# Altium schematic sheet CW341_FPGA_CFG.SchDoc
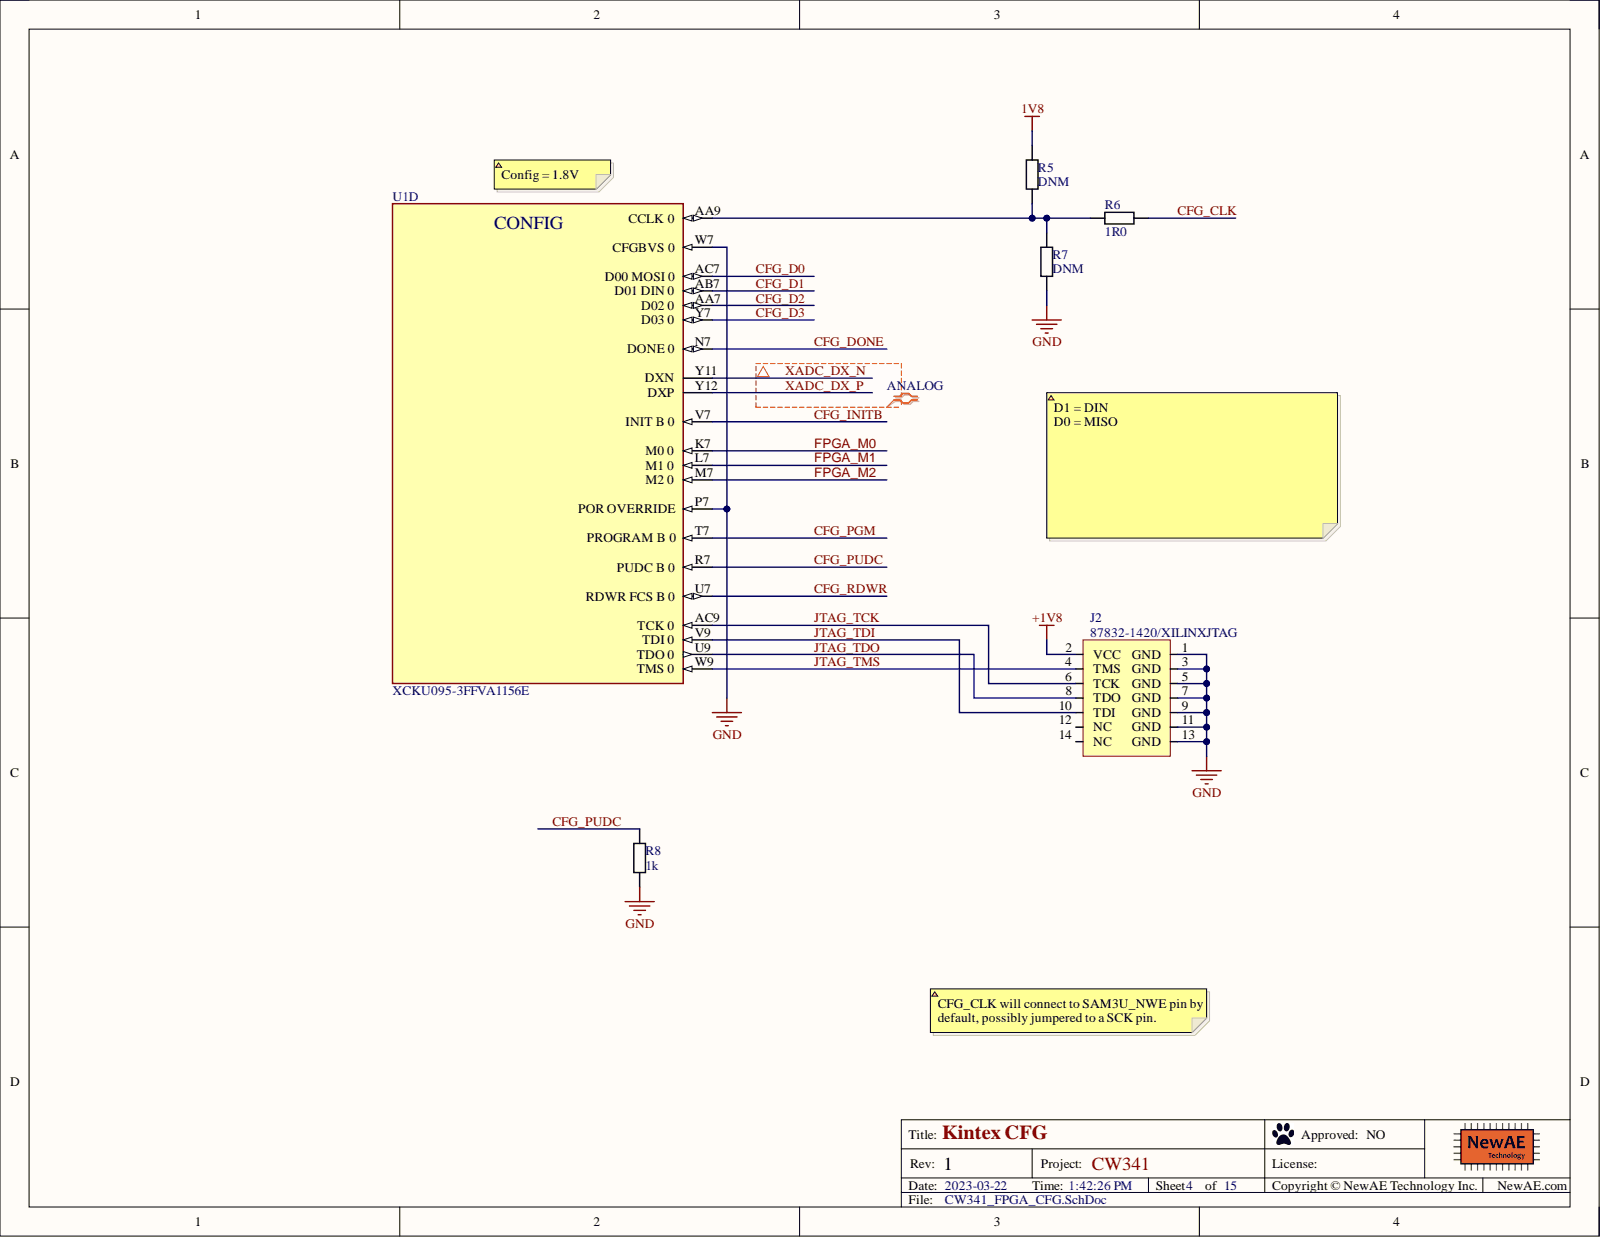
[diagram p0: CW341_FPGA_CFG.SchDoc]

## Parts
J2  87832-1420/XILINXJTAG  [87832-1420]
R5  DNM  [0402]
R6  1R0  [0402]
R7  DNM  [0402]
R8  1k  [0402]
U1  XCKU095-3FFVA1156E  Max Supply Voltage: 1.8V  [FP-XCKU060-3FFVA1156E-MFG]  (part D)

## Nets
1V8: J2.2(VCC) R5.1
GND: J2.1(GND) J2.3(GND) J2.5(GND) J2.7(GND) J2.9(GND) J2.11(GND) J2.13(GND) R7.2 R8.2 U1.P7(POR_OVERRIDE) U1.W7(CFGBVS_0)
CFG_CLK: R6.2
CFG_D0: U1.AC7(D00_MOSI_0)
CFG_D1: U1.AB7(D01_DIN_0)
CFG_D2: U1.AA7(D02_0)
CFG_D3: U1.Y7(D03_0)
CFG_DONE: U1.N7(DONE_0)
CFG_INITB: U1.V7(INIT_B_0)
CFG_PGM: U1.T7(PROGRAM_B_0)
CFG_PUDC: R8.1 U1.R7(PUDC_B_0)
CFG_RDWR: U1.U7(RDWR_FCS_B_0)
FPGA_M0: U1.K7(M0_0)
FPGA_M1: U1.L7(M1_0)
FPGA_M2: U1.M7(M2_0)
JTAG_TCK: J2.6(TCK) U1.AC9(TCK_0)
JTAG_TDI: J2.10(TDI) U1.V9(TDI_0)
JTAG_TDO: J2.8(TDO) U1.U9(TDO_0)
JTAG_TMS: J2.4(TMS) U1.W9(TMS_0)
XADC_DX_N: U1.Y11(DXN)
XADC_DX_P: U1.Y12(DXP)
NetR5_2: R5.2 R6.1 R7.1 U1.AA9(CCLK_0)

Not connected: J2 12(NC) 14(NC)

## Library data of the parts
J2 = 87832-1420/XILINXJTAG: Molex 14-pin SMD, JTAG Pinout; Component Kind: Standard; Library Name: CW1200_MainBoard.SCHLIB; Manufacture 1: Molex; Manufacture 1 Part Number: 87832-1420; Signal Integrity: Guessed Model; Supplier 1: Digi-Key; Supplier Part Number 1: WM18641-ND
R5 R7 = RCHIP-DNM-0402: Case-Metric: 1005; Component Group: Resistor; Database Table Name: main; Case-EIA: 0402; Component Kind: Standard; Component Type: Standard; Library Name: NewAE_AltiumLib.DBLib; Part Number: RCHIP-DNM-0402; Pin Count: 2; Value: DNM
R6 = RCHIP-1R0-0402: 1R0 res, 0402, 1%, 1/16W; Manufacture 1: Yageo; Manufacture Part Number 1: RC0402FR-071RL; Case-Metric: 1005; Component Group: Resistor; Database Table Name: main; Rated Power: 0.063W; Rated Voltage: 75VDC; Case-EIA: 0402; Component Kind: Standard; Component Type: Standard; Library Name: NewAE_AltiumLib.DBLib; Mounting Technology: SMT; Part Number: RCHIP-1R0-0402; Pin Count: 2; Supplier 1: Digi-Key; Supplier Part Number 1: 311-1.00LRCT-ND; Temperature Range: -55C to 155C; Tolerance: 1%; Value: 1R0
R8 = RCHIP-1K-0402: 1k res, 0402, 1%, 1/16W; Manufacture 1: Yageo; Manufacture Part Number 1: RC0402FR-071KL; Case-Metric: 1005; Component Group: Resistor; Database Table Name: main; Rated Power: 0.063W; Rated Voltage: 75VDC; Case-EIA: 0402; Component Kind: Standard; Component Type: Standard; Library Name: NewAE_AltiumLib.DBLib; Mounting Technology: SMT; Part Number: RCHIP-1K-0402; Pin Count: 2; Supplier 1: Digi-Key; Supplier Part Number 1: 311-1.00KLRCT-ND; Temperature Range: -55C to 155C; Tolerance: 1%; Value: 1k
U1 = IC-XCKU095-1156: IC FPGA 520 I/O 1156FCBGA; Manufacturer: Xilinx; Manufacturer Part Number: XCKU060-3FFVA1156E; Max Junction Temp: +100°C; Memory Type: RAM; Min Supply Voltage: 0.97V; Number Of I/Os: 520; Number Of Logic Elements Cells: 1176000; Ram Size: 38MBytes; Speed Grade: 3; Standoff Height: 0.4mm; Automotive: No; Category: IC; Component Kind: Standard; ComponentLink2Description: Datasheet; ComponentLink2URL: https://www.xilinx.com/support/documentation/data_sheets/ds890-ultrascale-overview.pdf; Device Class L1: Integrated Circuits (ICs); Device Class L2: Embedded Processors and Controllers; Device Class L3: FPGAs - Field Programmable Gate Arrays; Digikey Description: IC FPGA 520 I/O 1156FCBGA; Footprint Url: https://www.xilinx.com/support/documentation/user_guides/ug575-ultrascale-pkg-pinout.pdf; Height: 3.51mm; Ipc Land Pattern Name: BGA1156N100P34X34_3500X3500X342; Lead Free: Yes; Octopart: https://octopart.com/xcku035-3ffva1156e-xilinx-67038192?r=sp; Package: 0; RoHS: TRUE; Temperature: 0°C to +100°C; Temperature Range High: +100°C; Temperature Range Low: 0°C; Library Name: CW341_KintexUltraScaleTarget.SCHLIB
